# Altium schematic sheet Sheet5.SchDoc(Sheet5)
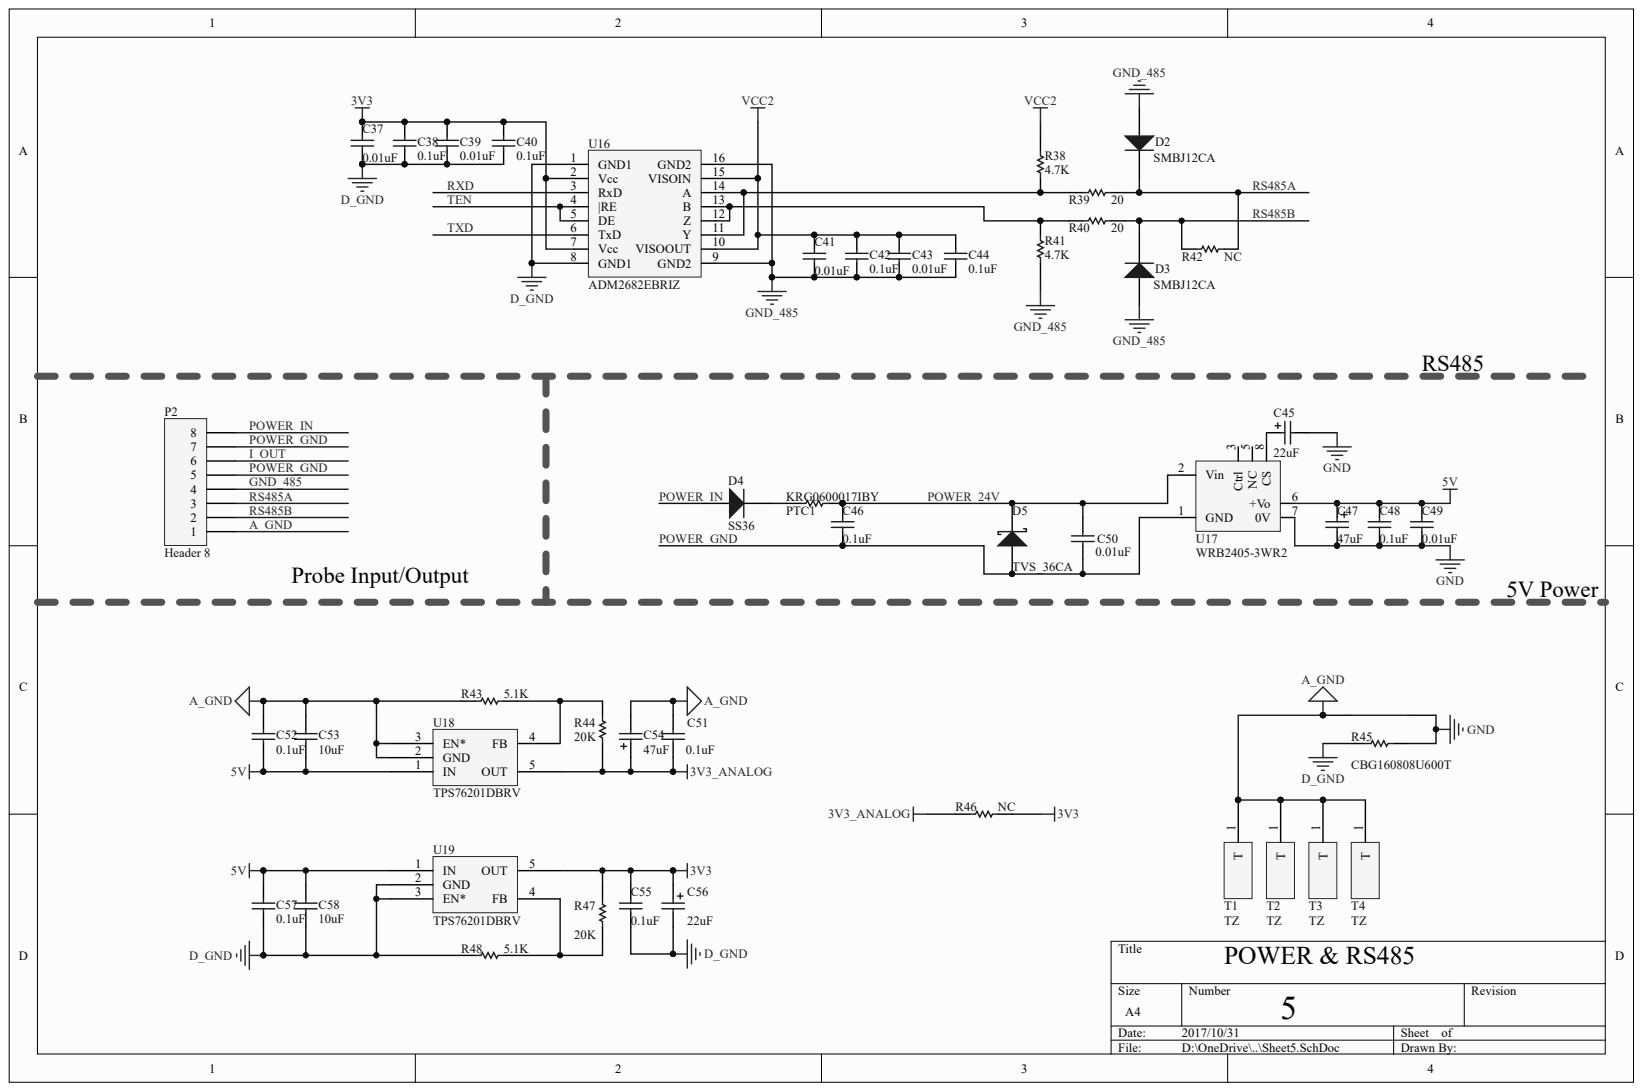
[diagram p0: Sheet5.SchDoc]

## Parts
C37  value 0.01uF  (hidden comment: Cap)
C38  value 0.1uF  (hidden comment: Cap)
C39  value 0.01uF  (hidden comment: Cap)
C40  value 0.1uF  (hidden comment: Cap)
C41  value 0.01uF  (hidden comment: Cap)
C42  value 0.1uF  (hidden comment: Cap)
C43  value 0.01uF  (hidden comment: Cap)
C44  value 0.1uF  (hidden comment: Cap)
C45  value 22uF  (hidden comment: Cap Pol2)
C46  value 0.1uF  (hidden comment: Cap)
C47  value 47uF  (hidden comment: Cap Pol2)
C48  value 0.1uF  (hidden comment: Cap)
C49  value 0.01uF  (hidden comment: Cap)
C50  value 0.01uF  (hidden comment: Cap)
C51  value 0.1uF  (hidden comment: Cap)
C52  value 0.1uF  (hidden comment: Cap)
C53  value 10uF  (hidden comment: Cap)
C54  value 47uF  (hidden comment: Cap Pol2)
C55  value 0.1uF  (hidden comment: Cap)
C56  value 22uF  (hidden comment: Cap Pol2)
C57  value 0.1uF  (hidden comment: Cap)
C58  value 10uF  (hidden comment: Cap)
D2  SMBJ12CA
D3  SMBJ12CA
D4  SS36
D5  TVS_36CA
P2  Header 8
PTC1  value KRG0600017IBY  (hidden comment: Res1)
R38  value 4.7K  (hidden comment: Res1)
R39  value 20  (hidden comment: Res1)
R40  value 20  (hidden comment: Res1)
R41  value 4.7K  (hidden comment: Res1)
R42  value NC  (hidden comment: Res1)
R43  value 5.1K  (hidden comment: Res1)
R44  value 20K  (hidden comment: Res1)
R45  value CBG160808U600T  (hidden comment: Magnetic beads)
R46  value NC  (hidden comment: Res1)
R47  value 20K  (hidden comment: Res1)
R48  value 5.1K  (hidden comment: Res1)
T1  TZ
T2  TZ
T3  TZ
T4  TZ
U16  ADM2682EBRIZ
U17  WRB2405-3WR2
U18  TPS76201DBRV
U19  TPS76201DBRV

## Nets
3V3: C37.2 C38.2 C39.2 C40.2 C55.2 C56.1 R46.2 R47.2 U16.2(Vcc) U16.7(Vcc) U19.5(OUT)
3V3_ANALOG: C51.1 C54.1 R44.1 R46.1 U18.5(OUT)
5V: C47.1 C48.2 C49.2 C52.1 C53.1 C57.2 C58.2 U17.6(+Vo) U18.1(IN) U19.1(IN)
D_GND: C37.1 C38.1 C39.1 C40.1 C55.1 C56.2 C57.1 C58.1 R45.1 R48.1 U16.1(GND1) U16.8(GND1) U19.2(GND) U19.3(EN*)
VCC2: C41.2 C42.2 C43.2 C44.2 R38.2 U16.10(VISOOUT) U16.15(VISOIN)
A_GND: C45.2 C47.2 C48.1 C49.1 C51.2 C52.2 C53.2 C54.2 P2.1 R43.1 R45.2 T1.1 T2.1 T3.1 T4.1 U17.7(0V) U18.2(GND) U18.3(EN*)
GND_485: C41.1 C42.1 C43.1 C44.1 D2.1 D3.1 P2.4 R41.1 U16.9(GND2) U16.16(GND2)
I_OUT: P2.6
POWER_24V: C46.2 C50.2 D5.2 PTC1.1 U17.2(Vin)
POWER_GND: C46.1 C50.1 D5.1 P2.5 P2.7 U17.1(GND)
POWER_IN: D4.1 P2.8
RS485A: D2.2 P2.3 R39.1 R42.1
RS485B: D3.2 P2.2 R40.1 R42.2
RXD: U16.3(RxD)
TEN: U16.4(|RE) U16.5(DE)
TXD: U16.6(TxD)
NetC45_1: C45.1 U17.8(CS)
NetD4_2: D4.2 PTC1.2
NetR38_1: R38.1 R39.2 U16.11(Y) U16.14(A)
NetR40_2: R40.2 R41.2 U16.12(Z) U16.13(B)
NetR43_2: R43.2 R44.2 U18.4(FB)
NetR47_1: R47.1 R48.2 U19.4(FB)

Not connected: U17 3(Ctrl) 5(NC)

## Library data of the parts
C37-C58 D2-D5 P2 PTC1 R38-R48 T1-T4 U16-U19 = (no symbol name)
  C37-C44 C46 C48-C53 C55 C57 C58: Published: 8-Jun-2000; LatestRevisionDate: 17-Jul-2002; LatestRevisionNote: Re-released for DXP Platform.; PackageReference: RAD-0.3
  C45 C47 C54 C56: Published: 8-Jun-2000; LatestRevisionDate: 17-Jul-2002; LatestRevisionNote: Re-released for DXP Platform.; PackageReference: POLAR0.8
  D2 D3: Published: 8-Jun-2000; LatestRevisionDate: 17-Jul-2002; LatestRevisionNote: Re-released for DXP Platform.; DatasheetVersion: 1998; PackageVersion: Aug-1999; PackageReference: DO-201AD; ComponentLink1Description: Manufacturer Link; ComponentLink1URL: http://www.fairchildsemi.com/; ComponentLink2Description: Datasheet; ComponentLink2URL: http://www.fairchildsemi.com/ds/1N%2F1N5400.pdf
  D4: Published: 8-Jun-2000; LatestRevisionDate: 17-Jul-2002; LatestRevisionNote: Re-released for DXP Platform.; DatasheetVersion: 1997; PackageReference: DO-35; Code_JEDEC: DO-204-AH; ComponentLink1Description: Manufacturer Link; ComponentLink1URL: http://www.fairchildsemi.com/; ComponentLink2Description: Datasheet; ComponentLink2URL: http://www.fairchildsemi.com/ds/1N%2F1N4148.pdf
  D5: Published: 8-Jun-2000; LatestRevisionDate: 17-Jul-2002; LatestRevisionNote: Re-released for DXP Platform.; PackageReference: SMB; Code_JEDEC: DO-214-AA
  P2: LatestRevisionDate: 17-Jul-2002; LatestRevisionNote: Re-released for DXP Platform.
  PTC1 R38-R48: Published: 8-Jun-2000; LatestRevisionDate: 17-Jul-2002; LatestRevisionNote: Re-released for DXP Platform.; PackageReference: AXIAL-0.3
  C37-C58 D2-D5 P2 PTC1 R38-R48: Publisher: Altium Limited
